# Altium schematic sheet 06_MCU.SchDoc(06_MCU)
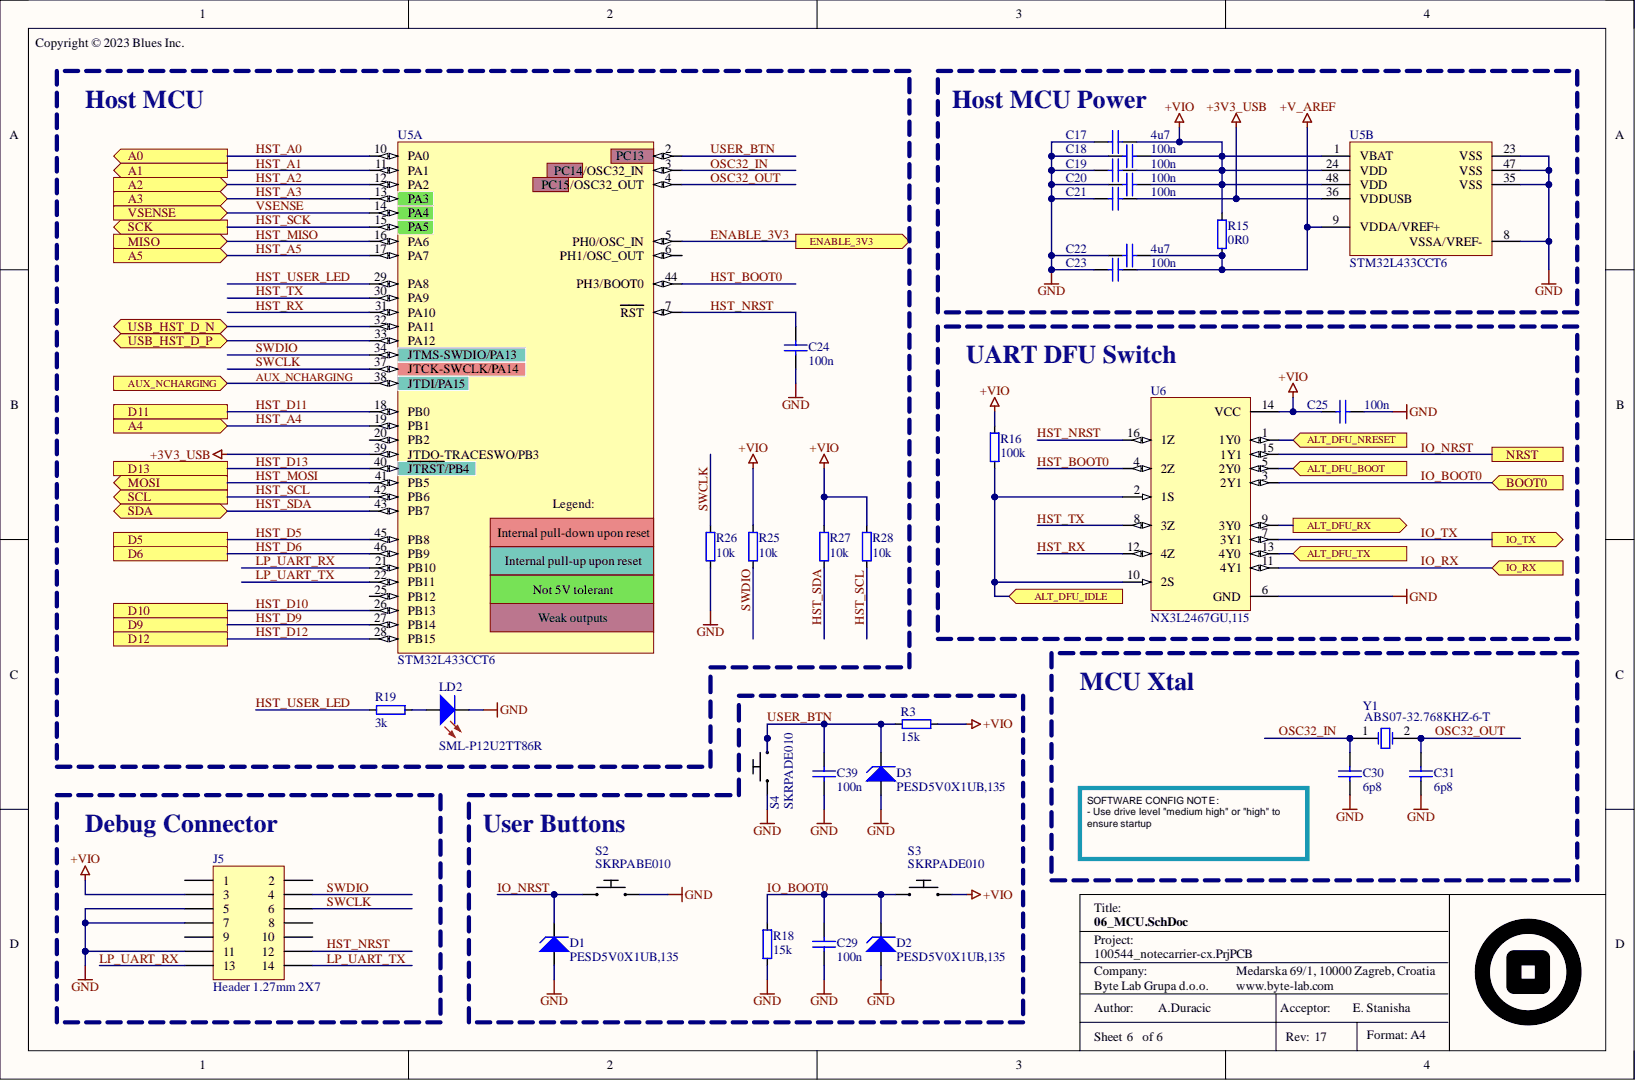
[diagram p0: 06_MCU.SchDoc]

## Parts
C17  4u7  [C-0603]
C18  100n  [C-0402]
C19  100n  [C-0402]
C20  100n  [C-0402]
C21  100n  [C-0402]
C22  4u7  [C-0603]
C23  100n  [C-0402]
C24  100n  [C-0402]
C25  100n  [C-0402]
C29  100n  [C-0402]
C30  6p8  [C-0402]
C31  6p8  [C-0402]
C39  100n  [C-0402]
D1  PESD5V0X1UB,135  [SOD-523F]
D2  PESD5V0X1UB,135  [SOD-523F]
D3  PESD5V0X1UB,135  [SOD-523F]
J5  Header 1.27mm 2X7  [Header 1.27mm 2X7 SMD]
LD2  SML-P12U2TT86R  [LED-0402]
R3  15k  [R-0402]
R15  0R0  [R-0603]
R16  100k  [R-0402]
R18  15k  [R-0402]
R19  3k  [R-0402]
R25  10k  [R-0402]
R26  10k  [R-0402]
R27  10k  [R-0402]
R28  10k  [R-0402]
S2  SKRPABE010  [Tact Switch SKRPADE010]
S3  SKRPADE010  [Tact Switch SKRPADE010]
S4  SKRPADE010  [Tact Switch SKRPADE010]
U5  STM32L433CCT6  [QFP50P900X900X160-48]  (parts A,B)
U6  NX3L2467GU,115  [QFN40P180X260X55-16N]
Y1  ABS07-32.768KHZ-6-T  [XTAL_3.2x1.5 MM]

## Ports
A0, A1, A2, A3, A4, A5, ALT_DFU_BOOT, ALT_DFU_IDLE, ALT_DFU_NRESET, ALT_DFU_RX, ALT_DFU_TX, AUX_NCHARGING, BOOT0, D5, D6, D9, D10, D11, D12, D13, ENABLE_3V3, IO_RX, IO_TX, MISO, MOSI, NRST, SCK, SCL, SDA, USB_HST_D_N, USB_HST_D_P, VSENSE

## Nets
+3V3_USB: C21.2 U5.36(VDDUSB) U5.39(JTDO-TRACESWO/PB3)
+V_AREF: C22.2 C23.2 R15.1 U5.9(VDDA/VREF+)
+VIO: C17.2 C18.2 C19.2 C20.2 C25.1 J5.3 R3.2 R15.2 R16.2 R25.2 R27.2 R28.2 S3.2 U5.1(VBAT) U5.24(VDD) U5.48(VDD) U6.14(VCC)
GND: C17.1 C18.1 C19.1 C20.1 C21.1 C22.1 C23.1 C24.1 C25.2 C29.1 C30.1 C31.1 C39.1 D1.1 D2.1 D3.1 J5.5 J5.7 J5.11 LD2.2 R18.2 R26.1 S2.2 S4.1 U5.8(VSSA/VREF-) U5.23(VSS) U5.35(VSS) U5.47(VSS) U6.6(GND)
ALT_DFU_BOOT: U6.5(2Y0) ; port ALT_DFU_BOOT
ALT_DFU_IDLE: R16.1 U6.2(1S) U6.10(2S) ; port ALT_DFU_IDLE
ALT_DFU_NRESET: U6.1(1Y0) ; port ALT_DFU_NRESET
ALT_DFU_RX: U6.9(3Y0) ; port ALT_DFU_RX
ALT_DFU_TX: U6.13(4Y0) ; port ALT_DFU_TX
AUX_NCHARGING: U5.38(JTDI/PA15) ; port AUX_NCHARGING
ENABLE_3V3: U5.5(PH0/OSC_IN) ; port ENABLE_3V3
HST_A0: U5.10(PA0) ; port A0
HST_A1: U5.11(PA1) ; port A1
HST_A2: U5.12(PA2) ; port A2
HST_A3: U5.13(PA3) ; port A3
HST_A4: U5.19(PB1) ; port A4
HST_A5: U5.17(PA7) ; port A5
HST_BOOT0: U5.44(PH3/BOOT0) U6.4(2Z)
HST_D5: U5.45(PB8) ; port D5
HST_D6: U5.46(PB9) ; port D6
HST_D9: U5.27(PB14) ; port D9
HST_D10: U5.26(PB13) ; port D10
HST_D11: U5.18(PB0) ; port D11
HST_D12: U5.28(PB15) ; port D12
HST_D13: U5.40(JTRST/PB4) ; port D13
HST_MISO: U5.16(PA6) ; port MISO
HST_MOSI: U5.41(PB5) ; port MOSI
HST_NRST: C24.2 J5.12 U5.7(RST) U6.16(1Z)
HST_RX: U5.31(PA10) U6.12(4Z)
HST_SCK: U5.15(PA5) ; port SCK
HST_SCL: R28.1 U5.42(PB6) ; port SCL
HST_SDA: R27.1 U5.43(PB7) ; port SDA
HST_TX: U5.30(PA9) U6.8(3Z)
HST_USER_LED: R19.2 U5.29(PA8)
IO_BOOT0: C29.2 D2.2 R18.1 S3.1 U6.3(2Y1) ; port BOOT0
IO_NRST: D1.2 S2.1 U6.15(1Y1) ; port NRST
IO_RX: U6.11(4Y1) ; port IO_RX
IO_TX: U6.7(3Y1) ; port IO_TX
LP_UART_RX: J5.13 U5.21(PB10)
LP_UART_TX: J5.14 U5.22(PB11)
OSC32_IN: C30.2 U5.3(PC14/OSC32_IN) Y1.1
OSC32_OUT: C31.2 U5.4(PC15/OSC32_OUT) Y1.2
SWCLK: J5.6 R26.2 U5.37(JTCK-SWCLK/PA14)
SWDIO: J5.4 R25.1 U5.34(JTMS-SWDIO/PA13)
USB_HST_D_N: U5.32(PA11) ; port USB_HST_D_N
USB_HST_D_P: U5.33(PA12) ; port USB_HST_D_P
USER_BTN: C39.2 D3.2 R3.1 S4.2 U5.2(PC13)
VSENSE: U5.14(PA4) ; port VSENSE
NetLD2_1: LD2.1 R19.1

Not connected: J5 1 2 8 9 10; U5 6(PH1/OSC_OUT) 20(PB2) 25(PB12)

## Library data of the parts
C17 C22 = C0603-4u7-10V-X5R: Capacitor ceramic 4u7 ±10% X5R 10V 0603; BL Part Number: 2003-721; Checked_By: Marsic; Drawn_By: Hamin; Component Kind: Standard; DateModified: 19-06-2023; Library Name: BL_Passive.SchLib; Manufacturer: N/A; Manufacturer Part Number: N/A
C18-C21 C23-C25 C29 C39 = C0402-100n-16V-X7R: Capacitor ceramic 100n ±10% X7R 16V 0402; BL Part Number: 2000-908; Checked_By: Dodig; Drawn_By: Puskar; Component Kind: Standard; DateModified: 27-08-2013; Library Name: BL_Passive.SchLib; Manufacturer: N/A; Manufacturer Part Number: N/A; Simulation: CAP; Supplier 1: Digi-Key; Supplier Part Number 1: 490-3261-1-ND
C30 C31 = C0402-6p8-50V-C0G: Capacitor ceramic 6.8p C0G 50V 0402; BL Part Number: 2001-291; Manufacturer: Samsung Electro-Mechanics; Manufacturer Part Number: CL05C6R8CB5NNNC; Checked_By: Zvonc; Drawn_By: Puskar; Component Kind: Standard; DateModified: 27-09-2013; Library Name: BL_Passive.SchLib; Simulation: CAP; Supplier 1: Digi-Key; Supplier Part Number 1: 1276-1721-1-ND
D1-D3 = PESD5V0X1UB,135: TVS DIODE 5VWM 8VC SOD523; BL Part Number: 2004-110; Manufacturer: Nexperia; Checked_By: Hamin; Drawn_By: Zvonc; Component Kind: Standard; DateModified: 17-10-2018; Library Name: BL_Active.SchLib; Manufacturer Part Number: PESD5V0X1UB,135; Supplier 1: Digi-Key; Supplier Part Number 1: 1727-5906-1-ND
J5 = Conn Header 1.27mm 2X7: 1.27mm pitch 14 pin (2x7) top entry wire to board connector SMD; BL Part Number: 2009-203; Manufacturer: SAMTEC; Manufacturer Part Number: FTSH-107-01-L-DV-K; Checked_By: Duracic; Drawn_By: Marsic; Component Kind: Standard; DateModified: 09-12-2025; Library Name: BL_Mechanical.SchLib
LD2 = LED_SML-P12U2TT86R: LED RED CLEAR SMD 0402; BL Part Number: 2006-852; Manufacturer: Rhom Semiconductor; Checked_By: Hlupic; Drawn_By: Marsic; Component Kind: Standard; DateModified: 12-10-2022; Library Name: BL_Active.SchLib; Manufacturer Part Number: SML-P12U2TT86R
R3 R18 = R0402-15k-1: Resistor 15k ±1% 0402; BL Part Number: 2001-157; Checked_By: Zvonc; Drawn_By: Puskar; Component Kind: Standard; DateModified: 23-01-2015; Library Name: BL_Passive.SchLib; Manufacturer: N/A; Manufacturer Part Number: N/A; Supplier 1: Digi-Key; Supplier Part Number 1: P15.0KLCT-ND
R15 = R0603-0R0: Resistor 0R0 0603; BL Part Number: 2000-723; Checked_By: Puskar; Drawn_By: Dodig; Component Kind: Standard; DateModified: 07-12-2013; Library Name: BL_Passive.SchLib; Manufacturer: N/A; Manufacturer Part Number: N/A; Supplier 1: Digi-Key; Supplier Part Number 1: 311-0.0GRCT-ND
R16 = R0402-100k-1: Resistor 100k ±1% 0402; BL Part Number: 2000-727; Checked_By: Dodig; Drawn_By: Puskar; Component Kind: Standard; DateModified: 27-10-2013; Library Name: BL_Passive.SchLib; Manufacturer: N/A; Manufacturer Part Number: N/A; Supplier 1: Digi-Key; Supplier Part Number 1: RHM100CDCT-ND
R19 = R0402-3k-1: Resistor 3k ±1% 0402; BL Part Number: 2001-570; Checked_By: Hamin; Drawn_By: Fumic; Component Kind: Standard; DateModified: 06-09-2021; Library Name: BL_Passive.SchLib; Manufacturer: N/A; Manufacturer Part Number: N/A
R25-R28 = R0402-10k-1: Resistor 10k ±1% 0402; BL Part Number: 2000-729; Checked_By: Dodig; Drawn_By: Puskar; Component Kind: Standard; DateModified: 27-08-2013; Library Name: BL_Passive.SchLib; Manufacturer: N/A; Manufacturer Part Number: N/A; Supplier 1: Digi-Key; Supplier Part Number 1: 311-10.0KLRCT-ND
S2 = Switch tact SKRPABE010: Tact Switch SPST-NO 0.05A 16V; BL Part Number: 2009-324; Manufacturer: Alps; Checked_By: Duracic; Drawn_By: Marsic; Component Kind: Standard; DateModified: 08-12-2025; Library Name: BL_Mechanical.SchLib; Manufacturer Part Number: SKRPABE010
S3 S4 = Switch tact SKRPADE010: Tact Switch SPST-NO 0.05A 16V; BL Part Number: 2007-145; Manufacturer: Alps; Checked_By: Hlupic; Drawn_By: Marsic; Component Kind: Standard; DateModified: 12-01-2023; Library Name: BL_Mechanical.SchLib; Manufacturer Part Number: SKRPADE010
U5 = STM32L433CCT6: MCU, 32b, M4, 256kB FLASH, 64kB RAM; BL Part Number: 2009-272; Manufacturer: STMicroelectronics; Checked_By: Duracic; Drawn_By: Marsic; Component Kind: Standard; DateModified: 09-01-2026; Library Name: BL_Digital.SchLib; Manufacturer Part Number: STM32L433CCT6
U6 = NX3L2467GU,115: IC ANALOG SWITCH DPDTX2 16XQFN; BL Part Number: 2009-148; Manufacturer: NXP; Checked_By: Duracic; Drawn_By: Marsic; Component Kind: Standard; DateModified: 26-11-2025; Library Name: BL_Digital.SchLib; Manufacturer Part Number: NX3L2467GU,115
Y1 = XTAL_ABS07-32.768KHZ-6-T: CRYSTAL 32.768KHZ 6PF SMD; BL Part Number: 2005-489; Manufacturer: Abracon Corporation; Checked_By: Hlupic; Drawn_By: Fumic; Component Kind: Standard; DateModified: 25-02-2021; Library Name: BL_Passive.SchLib; Manufacturer Part Number: ABS07-32.768KHZ-6-T
